# Altium schematic sheet Schematic Prints("All Documents",Physical)
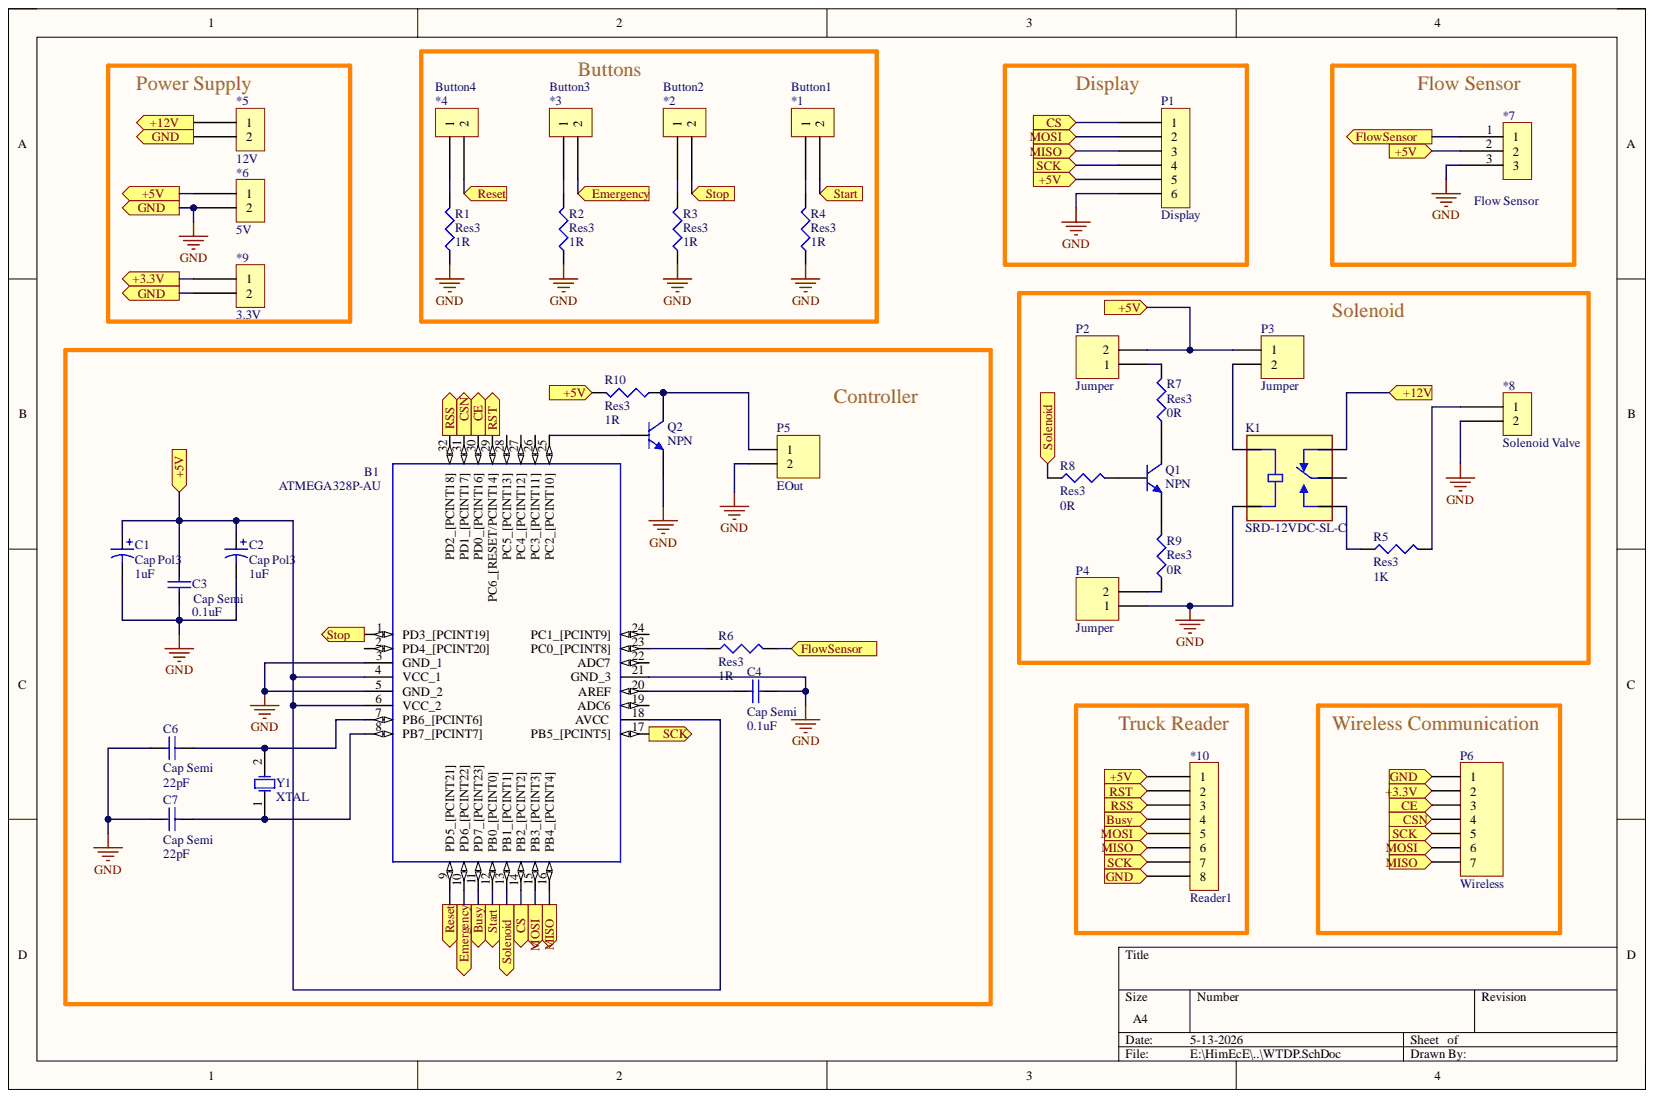
[diagram p0: Schematic Prints("All Documents",Physical)]

## Parts
*1  Button1  [HDR1X2]
*2  Button2  [HDR1X2]
*3  Button3  [HDR1X2]
*4  Button4  [HDR1X2]
*5  12V  [HDR1X2]
*6  5V  [HDR1X2]
*7  Flow Sensor  [HDR1X3]
*8  Solenoid Valve  [HDR1X2]
*9  3.3V  [HDR1X2]
*10  Reader1  [HDR1X8]
B1  ATMEGA328P-AU  [QFP80P900X900X120-32N]
C1  Cap Pol3  value 1uF  [C0805]
C2  Cap Pol3  value 1uF  [C0805]
C3  Cap Semi  value 0.1uF  [1608[0603]]
C4  Cap Semi  value 0.1uF  [1608[0603]]
C6  Cap Semi  value 22pF  [1608[0603]]
C7  Cap Semi  value 22pF  [1608[0603]]
K1  SRD-12VDC-SL-C  [RELAY_SRD-12VDC-SL-C]
P1  Display  [HDR1X6]
P2  Jumper  [HDR1X2]
P3  Jumper  [HDR1X2]
P4  Jumper  [HDR1X2]
P5  EOut  [HDR1X2]
P6  Wireless  [HDR1X7]
Q1  NPN  [TO-226-AA]
Q2  NPN  [TO-226-AA]
R1  Res3  value 1R  [J1-0603]
R2  Res3  value 1R  [J1-0603]
R3  Res3  value 1R  [J1-0603]
R4  Res3  value 1R  [J1-0603]
R5  Res3  value 1K  [J1-0603]
R6  Res3  value 1R  [J1-0603]
R7  Res3  value 0R  [J1-0603]
R8  Res3  value 0R  [J1-0603]
R9  Res3  value 0R  [J1-0603]
R10  Res3  value 1R  [J1-0603]
Y1  XTAL  [R38]

## Nets
GND: *5.2 *6.2 *7.3 *8.2 *9.2 *10.8 B1.3(GND_1) B1.5(GND_2) B1.21(GND_3) C1.2 C2.2 C3.1 C4.2 C6.1 C7.1 K1.COIL2 P1.6 P4.1 P5.2 P6.1 Q2.3 R1.1 R2.1 R3.1 R4.1
Net*1_1: *1.1 R4.2
Net*1_2: *1.2 B1.12(PB0_[PCINT0])
Net*2_1: *2.1 R3.2
Net*2_2: *2.2 B1.1(PD3_[PCINT19])
Net*3_1: *3.1 R2.2
Net*3_2: *3.2 B1.10(PD6_[PCINT22])
Net*4_1: *4.1 R1.2
Net*4_2: *4.2 B1.9(PD5_[PCINT21])
Net*5_1: *5.1 K1.NC
Net*6_1: *6.1 *7.2 *10.1 B1.4(VCC_1) B1.6(VCC_2) B1.18(AVCC) C1.1 C2.1 C3.2 P1.5 P2.2 P3.1 R10.2
Net*7_1: *7.1 R6.2
Net*8_1: *8.1 R5.2
Net*9_1: *9.1 P6.2
Net*10_2: *10.2 B1.29(PC6_[RESET/PCINT14])
Net*10_3: *10.3 B1.32(PD2_[PCINT18])
Net*10_4: *10.4 B1.11(PD7_[PCINT23])
Net*10_5: *10.5 B1.15(PB3_[PCINT3]) P1.2 P6.6
Net*10_6: *10.6 B1.16(PB4_[PCINT4]) P1.3 P6.7
Net*10_7: *10.7 B1.17(PB5_[PCINT5]) P1.4 P6.5
NetB1_2: B1.2(PD4_[PCINT20])
NetB1_7: B1.7(PB6_[PCINT6]) C6.2 Y1.2
NetB1_8: B1.8(PB7_[PCINT7]) C7.2 Y1.1
NetB1_13: B1.13(PB1_[PCINT1]) R8.1
NetB1_14: B1.14(PB2_[PCINT2]) P1.1
NetB1_19: B1.19(ADC6)
NetB1_20: B1.20(AREF) C4.1
NetB1_22: B1.22(ADC7)
NetB1_23: B1.23(PC0_[PCINT8]) R6.1
NetB1_24: B1.24(PC1_[PCINT9])
NetB1_25: B1.25(PC2_[PCINT10]) Q2.2
NetB1_26: B1.26(PC3_[PCINT11])
NetB1_27: B1.27(PC4_[PCINT12])
NetB1_28: B1.28(PC5_[PCINT13])
NetB1_30: B1.30(PD0_[PCINT16]) P6.3
NetB1_31: B1.31(PD1_[PCINT17]) P6.4
NetK1_COIL1: K1.COIL1 P3.2
NetK1_COM: K1.COM
NetK1_NO: K1.NO R5.1
NetP2_1: P2.1 R7.2
NetP4_2: P4.2 R9.1
NetP5_1: P5.1 Q2.1 R10.1
NetQ1_1: Q1.1 R7.1
NetQ1_2: Q1.2 R8.2
NetQ1_3: Q1.3 R9.2

## Library data of the parts
*1-*6 *8 *9 = Header 2x1: Library Name: Headers.SchLib
  *1-*4 *6 *8 *9: Component Kind: Standard
  *5: Component Kind: Net Tie
*7 = Header 1x3: Component Kind: Standard; Library Name: Headers.SchLib
*10 = Header 1x8: Component Kind: Standard; Library Name: Headers.SchLib
B1 = ATMEGA328P-AU: MICROCHIP - ATMEGA328P-AU. - MICROCONTROLLER MCU, 8 BIT, ATMEGA, 20MHZ, TQFP-32; Geometry.Height: 1.2mm; Component Kind: Standard; Datasheet Link: https://componentsearchengine.com/Datasheets/2/ATMEGA328P-AU.pdf; Library Name: ATMEGA328P-AU.SchLib; Manufacturer_Name: Microchip; Manufacturer_Part_Number: ATMEGA328P-AU; Mouser Part Number: 556-ATMEGA328P-AU; Mouser Price/Stock: https://www.mouser.com/Search/Refine.aspx?Keyword=556-ATMEGA328P-AU; RS Part Number: 1310271; RS Price/Stock: http://uk.rs-online.com/web/p/products/1310271
C1 C2 = Cap Pol3: Polarized Capacitor (Surface Mount); Component Kind: Standard; LatestRevisionDate: 29-May-2009; LatestRevisionNote: IPC-7351 Footprint Added.; Library Name: Miscellaneous Devices.IntLib; PackageDescription: Chip Capacitor; PackageReference: C0805; Published: 8-Jun-2000; Signal Integrity: Cap Pol; Simulation: CAP; Publisher: Altium Limited
C3 C4 C7 = Cap Semi: Capacitor (Semiconductor SIM Model); Component Kind: Standard; LatestRevisionDate: 29-May-2009; LatestRevisionNote: IPC-7351 Footprint Added.; Library Name: Miscellaneous Devices.IntLib; PackageDescription: Chip Capacitor; PackageReference: C1206; Published: 8-Jun-2000; Signal Integrity: Cap Semi; Simulation: CAP; Publisher: Altium Limited
C6 = Cap Semi: Capacitor (Semiconductor SIM Model); Value: 22pF; Component Kind: Standard; LatestRevisionDate: 29-May-2009; LatestRevisionNote: IPC-7351 Footprint Added.; Library Name: Miscellaneous Devices.IntLib; PackageDescription: Chip Capacitor; PackageReference: C1206; Published: 8-Jun-2000; Signal Integrity: Cap Semi; Simulation: CAP; Publisher: Altium Limited
K1 = SRD-12VDC-SL-C: General Purpose Non Latching 12VDC SPDT Through Hole Relays RoHS; MF: Songle Relay; Check_prices: https://www.snapeda.com/parts/SRD-12VDC-SL-C/Songle+Relay/view-part/?ref=eda; Component Kind: Standard; Library Name: SRD-12VDC-SL-C.IntLib; MP: SRD-12VDC-SL-C; Package: NON STANDARD-5 Songle Relay; SnapEDA_Link: https://www.snapeda.com/parts/SRD-12VDC-SL-C/Songle+Relay/view-part/?ref=snap
P1 = Header 1x6: Component Kind: Standard; Library Name: Headers.SchLib
P2-P5 = Header 2: Header, 2-Pin; Component Kind: Standard; LatestRevisionDate: 17-Jul-2002; LatestRevisionNote: Re-released for DXP Platform.; Library Name: Miscellaneous Connectors.IntLib; Signal Integrity: Connector; Publisher: Altium Limited
P6 = Header 7: Header, 7-Pin; Component Kind: Standard; LatestRevisionDate: 17-Jul-2002; LatestRevisionNote: Re-released for DXP Platform.; Library Name: Miscellaneous Connectors.IntLib; Signal Integrity: Connector; Publisher: Altium Limited
Q1 Q2 = NPN: NPN Bipolar Transistor; Code_JEDEC: TO-226-AA; Component Kind: Standard; LatestRevisionDate: 17-Jul-2002; LatestRevisionNote: Re-released for DXP Platform.; Library Name: Miscellaneous Devices.IntLib; PackageDescription: TO, Flat Index; 3 In-Line, Axial Leads; Body Dia. 4.8mm; Leads 0.55 x 0.51 mm (max); PackageReference: TO-226-AA; Published: 8-Jun-2000; Signal Integrity: NPN; Simulation: NPN; Publisher: Altium Limited
R1-R5 R7-R9 = Res3: Resistor; Component Kind: Standard; LatestRevisionDate: 29-May-2009; LatestRevisionNote: IPC-7351 Footprint Added.; Library Name: Miscellaneous Devices.IntLib; PackageDescription: Chip Resistor; PackageReference: J1-0603; Published: 8-Jun-2000; Signal Integrity: Res; Simulation: RESISTOR; Publisher: Altium Limited
R6 R10 = Res3: Resistor; Value: 1R; Component Kind: Standard; LatestRevisionDate: 29-May-2009; LatestRevisionNote: IPC-7351 Footprint Added.; Library Name: Miscellaneous Devices.IntLib; PackageDescription: Chip Resistor; PackageReference: J1-0603; Published: 8-Jun-2000; Signal Integrity: Res; Simulation: RESISTOR; Publisher: Altium Limited
Y1 = XTAL: Crystal Oscillator; Component Kind: Standard; LatestRevisionDate: 17-Jul-2002; LatestRevisionNote: Re-released for DXP Platform.; Library Name: Miscellaneous Devices.IntLib; PackageDescription: Crystal, Thru-Hole; 2 Leads; Body 3.1 x 8.2 mm (Dia.xH); PackageReference: R38; Published: 8-Jun-2000; Simulation: XTAL; Publisher: Altium Limited
